# Altium schematic sheet Car.SchDoc
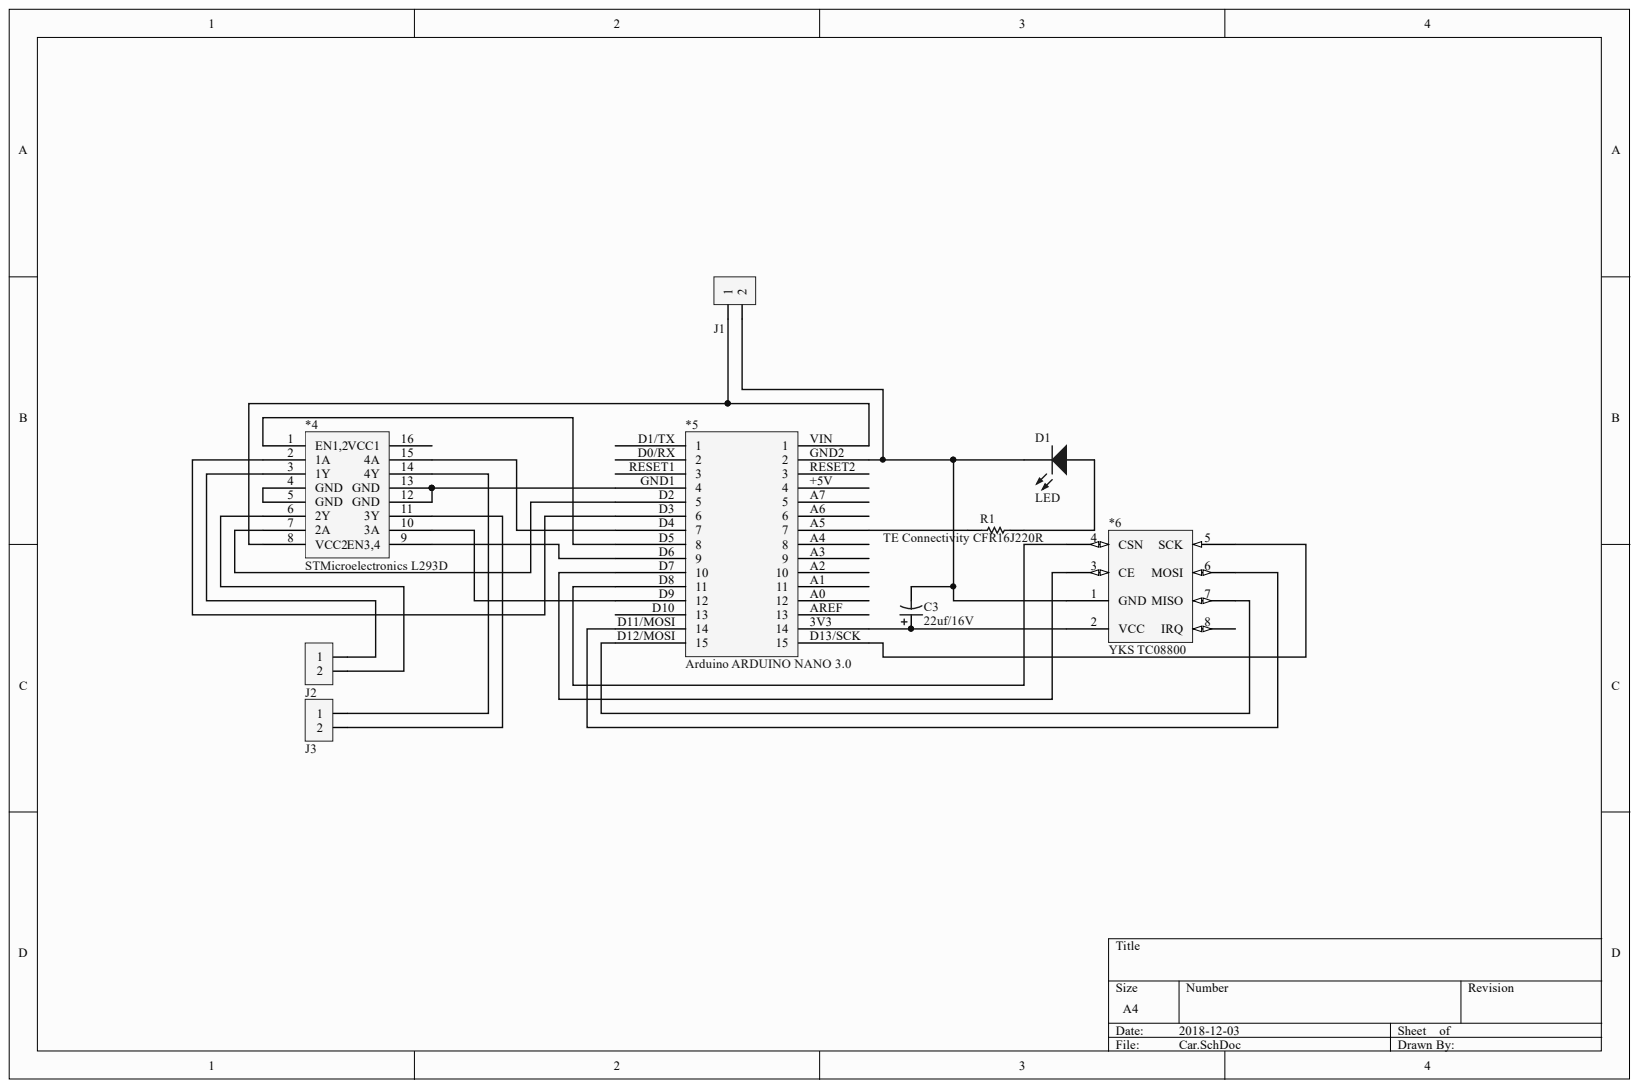
[diagram p0: Car.SchDoc]

## Parts
*4  STMicroelectronics L293D  [N16]
*5  Arduino ARDUINO NANO 3.0  [PCBComponent_1 - duplicate]
*6  YKS TC08800  [PCB-pr7ep0xgk71wl34p5oug-1]
C3  22uf/16V  [PCB-w1mz1f6kibge3ckcia7t-1]
D1  LED  [PCB-7mgmpbi0oy4qklr35z73-1]
J1  Würth Elektronik 61300211121  [PCB-dhbj8ha7d9lvo51vb5c4-1]  (value not drawn)
J2  Würth Elektronik 61300211121  [PCB-dhbj8ha7d9lvo51vb5c4-1]  (value not drawn)
J3  Würth Elektronik 61300211121  [PCB-dhbj8ha7d9lvo51vb5c4-1]  (value not drawn)
R1  TE Connectivity CFR16J220R  [PCB-heukol1j5k5ii1momt5n-1]

## Nets
Net*4_1: *4.1(EN1,2) *5.D5
Net*4_2: *4.2(1A) *5.D3
Net*4_3: *4.3(1Y) J2.1
Net*4_4: *4.4(GND) *4.5(GND)
Net*4_6: *4.6(2Y) J2.2
Net*4_7: *4.7(2A) *5.D2
Net*4_8: *4.8(VCC2) *5.VIN J1.1
Net*4_9: *4.9(EN3,4) *5.D6
Net*4_10: *4.10(3A) *5.D9
Net*4_11: *4.11(3Y) J3.2
Net*4_12: *4.12(GND) *4.13(GND) *5.GND1
Net*4_14: *4.14(4Y) J3.1
Net*4_15: *4.15(4A) *5.D4
Net*5_3V3: *5.3V3 *6.2(VCC) C3.1
Net*5_A5: *5.A5 R1.P$1
Net*5_D7: *5.D7 *6.3(CE)
Net*5_D8: *5.D8 *6.4(CSN)
Net*5_D11/MOSI: *5.D11/MOSI *6.6(MOSI)
Net*5_D12/MOSI: *5.D12/MOSI *6.7(MISO)
Net*5_D13/SCK: *5.D13/SCK *6.5(SCK)
Net*5_GND2: *5.GND2 *6.1(GND) C3.2 D1.2 J1.2
NetD1_1: D1.1 R1.P$2

Not connected: *4 16(VCC1); *5 +5V A0 A1 A2 A3 A4 A6 A7 AREF D0/RX D1/TX D10 RESET1 RESET2; *6 8(IRQ)

## Library data of the parts
*4 = L293D: Original ST Microelectronics RoHS Compliant, Pb Free Driver 4 Channel Integrated Circuit, 36V, 16-PIN DIP Package; Supply Voltage (DC): 36.0 V (max); Case/Package: PDIP; Component Kind: Standard; Library Name: Octopart library; Manufacturer Part Number: L293D
*5 = ARDUINO NANO 3.0: Arduino Nano; Component Kind: Standard; Library Name: Octopart library; Manufacturer Part Number: ARDUINO NANO
*6 = TC08800: Arduino NRF24L01+ 2.4GHz Antenna Wireless Transceiver Module For Microcontroll; Component Kind: Standard; Library Name: Octopart library; OctopartID: fde03023fed42227; Octopart URL: http://octopart.com/tc08800-yks-80291410
C3 = UPW1C100MDD: Cap Aluminum Lytic 22uF 16V 20% (5 X 11mm) Radial 2mm 180mA 2000h 105?C Automotive Bulk; Component Kind: Standard; Library Name: Octopart library; OctopartID: 295de054142df69a
D1 = TLHG5205: LED; 5mm; green; 40-50mcd; 14°; Front: convex; Pitch: 2.54mm; Peak Wavelength: 565 nm; Color: Green; Component Kind: Standard; Library Name: Octopart library; Manufacturer Part Number: TLHG5205; Mounting Style: Through Hole, Radial; Number of Pins: 2
J1-J3 = 61300211121: THT Vertical Pin Header WR-PHD, Pitch 2.54 mm, Single Row, 2 pins; Contact Resistance: 20.0 mΩ; Current Rating: 3.00 A; Gender: Male; Lead-Free Status: Lead Free; Pin Pitch: 2.54 mm; Component Kind: Standard; Contact Material: Copper; Contact Plating: Gold; Library Name: Octopart library; Manufacturer Part Number: 61300211121; Number of Pins: 2; OctopartID: 748fbcb73d6bab48; Octopart URL: https://octopart.com/61300211121-w%C3%BCrth+elektronik-20081794; Operating Temperature: -40.0 °C (min); Orientation: Vertical; Packaging: Bag; REACH SVHC Compliance: No SVHC
R1 = CFR16J220R: Through Hole Carbon Film Resistor, CFR Series, 220 ohm, 250 mW, - 5%, 200 V, Axial Leaded; Component Kind: Standard; Library Name: Octopart library
